# Altium schematic sheet Gecko IO.SchDoc
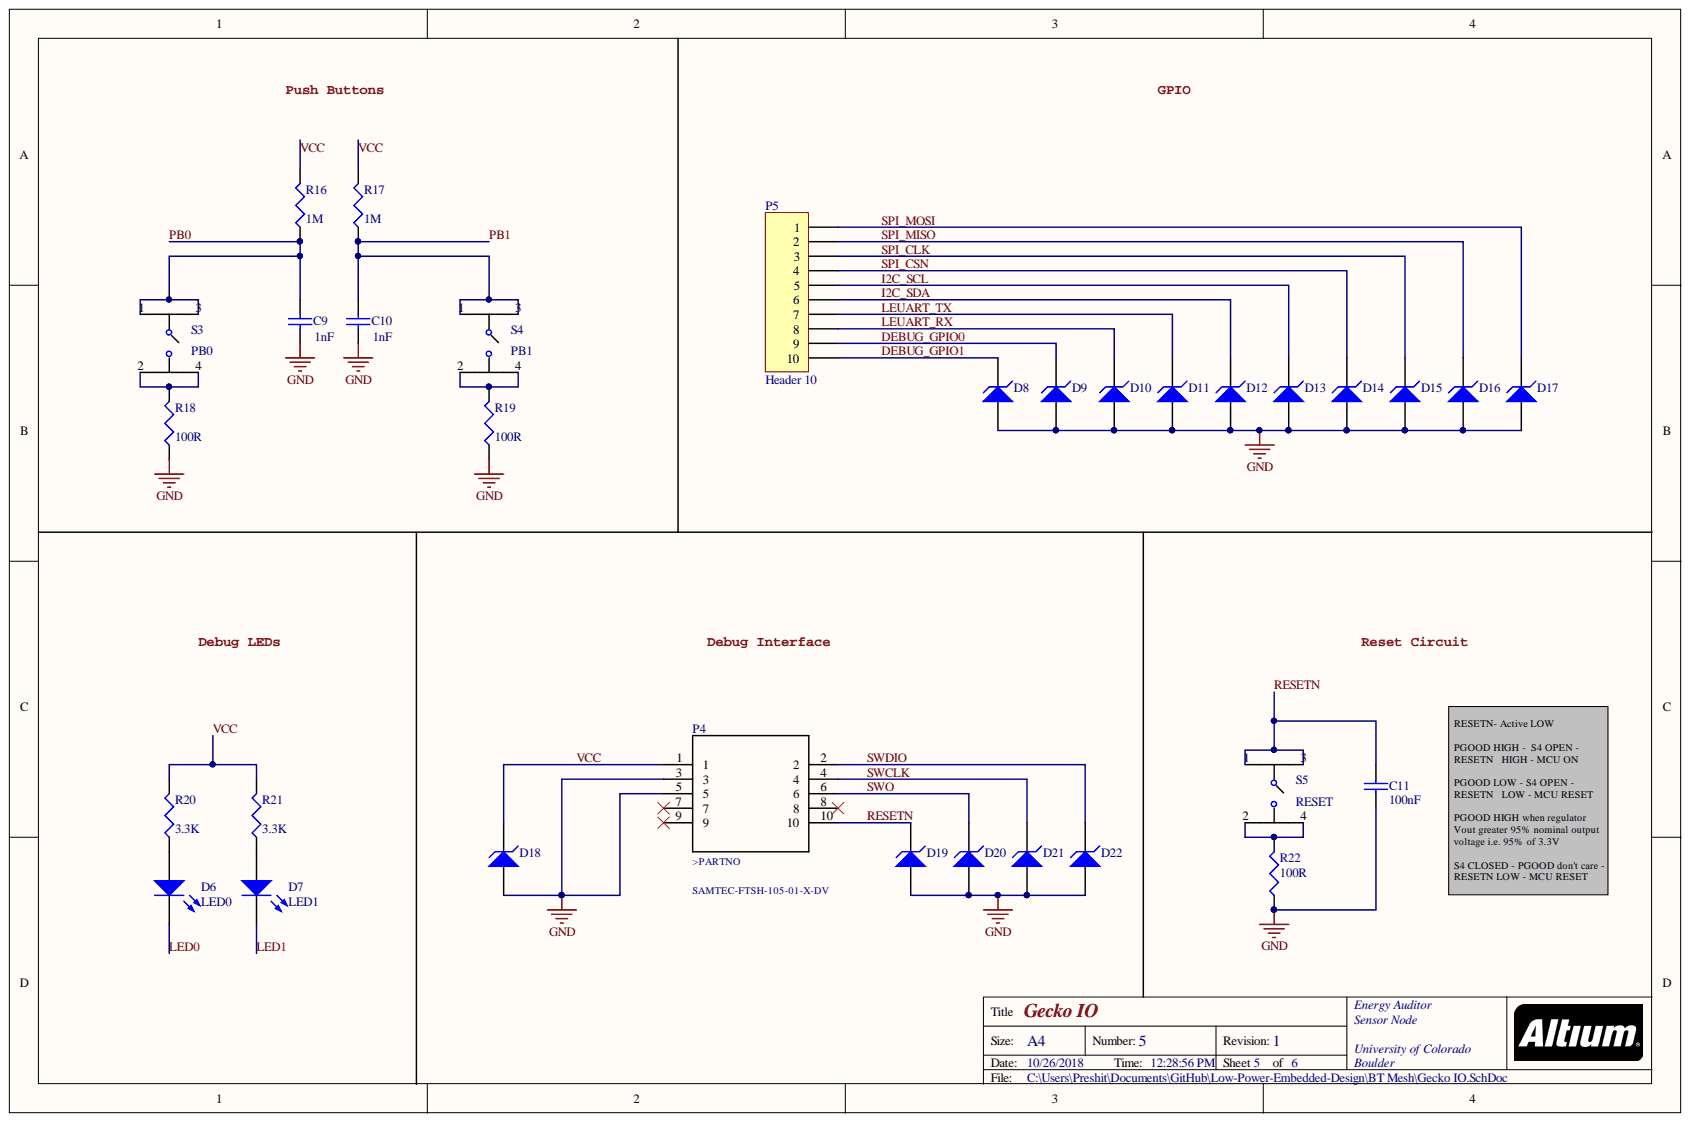
[diagram p0: Gecko IO.SchDoc]

## Parts
C9  1nF  [1608[0603]]
C10  1nF  [1608[0603]]
C11  100nF  [1608[0603]]
D6  LED0  [3.2X1.6X1.1]
D7  LED1  [3.2X1.6X1.1]
D8  D Zener  [0402]  (value not drawn)
D9  D Zener  [0402]  (value not drawn)
D10  D Zener  [0402]  (value not drawn)
D11  D Zener  [0402]  (value not drawn)
D12  D Zener  [0402]  (value not drawn)
D13  D Zener  [0402]  (value not drawn)
D14  D Zener  [0402]  (value not drawn)
D15  D Zener  [0402]  (value not drawn)
D16  D Zener  [0402]  (value not drawn)
D17  D Zener  [0402]  (value not drawn)
D18  D Zener  [0402]  (value not drawn)
D19  D Zener  [0402]  (value not drawn)
D20  D Zener  [0402]  (value not drawn)
D21  D Zener  [0402]  (value not drawn)
D22  D Zener  [0402]  (value not drawn)
P4  SAMTEC-FTSH-105-01-X-DV  [SAMTEC-FTSH-105-01-X-DV]
P5  Header 10  [HDR1X10]
R16  1M  [1608[0603]]
R17  1M  [1608[0603]]
R18  100R  [1608[0603]]
R19  100R  [1608[0603]]
R20  3.3K  [1608[0603]]
R21  3.3K  [1608[0603]]
R22  100R  [1608[0603]]
S3  PB0  [430182043816]
S4  PB1  [430182043816]
S5  RESET  [430182043816]

## Nets
GND: C9.1 C10.1 C11.2 D8.1 D9.1 D10.1 D11.1 D12.1 D13.1 D14.1 D15.1 D16.1 D17.1 D18.1 D19.1 D20.1 D21.1 D22.1 P4.3 P4.5 R18.1 R19.1 R22.1
DEBUG_GPIO0: D9.2 P5.9
DEBUG_GPIO1: D8.2 P5.10
I2C_SCL: D13.2 P5.5
I2C_SDA: D12.2 P5.6
LED0: D6.2
LED1: D7.2
LEUART_RX: D10.2 P5.8
LEUART_TX: D11.2 P5.7
PB0: C9.2 R16.1 S3.1 S3.3
PB1: C10.2 R17.1 S4.1 S4.3
RESETN: C11.1 D19.2 P4.10 S5.1 S5.3
SPI_CLK: D15.2 P5.3
SPI_CSN: D14.2 P5.4
SPI_MISO: D16.2 P5.2
SPI_MOSI: D17.2 P5.1
SWCLK: D21.2 P4.4
SWDIO: D22.2 P4.2
SWO: D20.2 P4.6
VCC: D18.2 P4.1 R16.2 R17.2 R20.2 R21.2
NetD6_1: D6.1 R20.1
NetD7_1: D7.1 R21.1
NetR18_2: R18.2 S3.2 S3.4
NetR19_2: R19.2 S4.2 S4.4
NetR22_2: R22.2 S5.2 S5.4

Not connected: P4 7 8 9

## Library data of the parts
C9 C10 = Cap: CAP CER 1000PF 50V X7R 0603; Manufacturer: AVX Corporation; Manufacturer Part Number: 06035C102JAT2A; Component Kind: Standard; ComponentLink1Description: Digi-Key Link; ComponentLink1URL: https://www.digikey.com/product-detail/en/avx-corporation/06035C102JAT2A/478-5006-1-ND/1888217; Digi-Key Part Number: 478-5006-1-ND; Library Name: Miscellaneous Devices.IntLib; Signal Integrity: Cap; Simulation: CAP; Value: 1nF
C11 = Cap: CAP CER 0.1UF 16V X7R 0603; Manufacturer: AVX Corporation; Manufacturer Part Number: 0603YC104MAT4A; Component Kind: Standard; ComponentLink1Description: Digi-Key Link; ComponentLink1URL: https://www.digikey.com/products/en/capacitors/ceramic-capacitors/60?k=capacitor&k=&pkeyword=capacitor&pv2049=u0.1%C2%B5F&sf=0&FV=c0005%2C1c0002%2C380009%2C400005%2C1f140000%2Cffe0003c&quantity=&ColumnSort=0&page=1&stock=1&pageSize=25; Digi-Key Part Number: 478-7617-1-ND; Library Name: Miscellaneous Devices.IntLib; Signal Integrity: Cap; Simulation: CAP; Value: 100nF
D6 D7 = LED2: LED GREEN DIFFUSED 0603 SMD; Manufacturer: OSRAM Opto Semiconductors Inc.; Manufacturer Part Number: LG L29K-F2J1-24-Z; Component Kind: Standard; ComponentLink1Description: Digi-Key Link; ComponentLink1URL: https://www.digikey.com/product-detail/en/osram-opto-semiconductors-inc/LG-L29K-F2J1-24-Z/475-3118-1-ND/4899549; Digi-Key Part Number: 475-3118-1-ND; Library Name: Miscellaneous Devices.IntLib; Simulation: LED2
D8-D22 = D Zener: TVS DIODE 5.5V 14V 2X1SON; Manufacturer: Texas Instruments; Manufacturer Part Number: TPD1E10B06DPYR; Component Kind: Standard; ComponentLink1Description: Digi-Key Link; ComponentLink1URL: https://www.digikey.com/product-detail/en/texas-instruments/TPD1E10B06DPYR/296-30406-1-ND/3283560; Digi-Key Part Number: 296-30406-1-ND; Library Name: Miscellaneous Devices.IntLib; Signal Integrity: D Zener; Simulation: ZENER
P4 = SAMTEC-FTSH-105-01-X-DV: .050" X .050 TERMINAL STRIP; Manufacturer: Samtec; Manufacturer Part Number: FTSH-105-01-F-DV-K-TR; Component Kind: Standard; ComponentLink1Description: Digi-Key Link; ComponentLink1URL: https://www.digikey.com/products/en/connectors-interconnects/rectangular-connectors-headers-male-pins/314?k=FTSH-105-01-F-DV-K-TR&k=&pkeyword=FTSH-105-01-F-DV-K-TR&pv7=2&sf=0&quantity=&ColumnSort=0&page=1&pageSize=25; Digi-Key Part Number: SAM13160CT-ND; Library Name: Debug_connector.SchLib
P5 = Header 10: Header, 10-Pin; Component Kind: Standard; Library Name: Miscellaneous Connectors.IntLib; Signal Integrity: Connector
R16 R17 = Res3: RES SMD 1M OHM 5% 1/10W 0603; Manufacturer: Samsung Electro-Mechanics; Manufacturer Part Number: RC1608J105CS; Component Kind: Standard; ComponentLink1Description: Digi-Key Link; ComponentLink1URL: https://www.digikey.com/product-detail/en/samsung-electro-mechanics/RC1608J105CS/1276-5134-1-ND/3968106; Digi-Key Part Number: 1276-5134-1-ND; Library Name: Miscellaneous Devices.IntLib; Signal Integrity: Res; Simulation: RESISTOR; Value: 1M
R18 R19 R22 = Res3: RES SMD 100 OHM 5% 1/10W 0603; Manufacturer: Vishay Dale; Manufacturer Part Number: CRCW0603100RJNEAC; Component Kind: Standard; ComponentLink1Description: Digi-Key Link; ComponentLink1URL: https://www.digikey.com/product-detail/en/vishay-dale/CRCW0603100RJNEAC/541-4059-1-ND/7928742; Digi-Key Part Number: 541-4059-1-ND; Library Name: Miscellaneous Devices.IntLib; Signal Integrity: Res; Simulation: RESISTOR; Value: 100R
R20 R21 = Res3: RES SMD 3.3K OHM 5% 1/10W 0603; Manufacturer: Panasonic Electronic Components; Manufacturer Part Number: ERJ-3GEYJ332V; Component Kind: Standard; ComponentLink1Description: Digi-Key Link; ComponentLink1URL: https://www.digikey.com/product-detail/en/panasonic-electronic-components/ERJ-3GEYJ332V/P3.3KGCT-ND/135146; Digi-Key Part Number: P3.3KGCT-ND; Library Name: Miscellaneous Devices.IntLib; Signal Integrity: Res; Simulation: RESISTOR; Value: 3.3K
S3-S5 = 430182043816: SWITCH TACTILE SPST-NO 0.05A 12V; Manufacturer: Wurth Electronics; Component Kind: Standard; ComponentLink1Description: Digi-Key Link; ComponentLink1URL: https://www.digikey.com/products/en/switches/tactile-switches/197?k=430+182+043+816&k=&pkeyword=430+182+043+816&pv7=2&sf=0&quantity=&ColumnSort=0&page=1&pageSize=25; Digi-Key Part Number: 732-7004-1-ND; Library Name: 430182043816.SchLib; Manufacturer Part Number: 430182043816
